# Altium schematic sheet Connector.SchDoc(Connector)
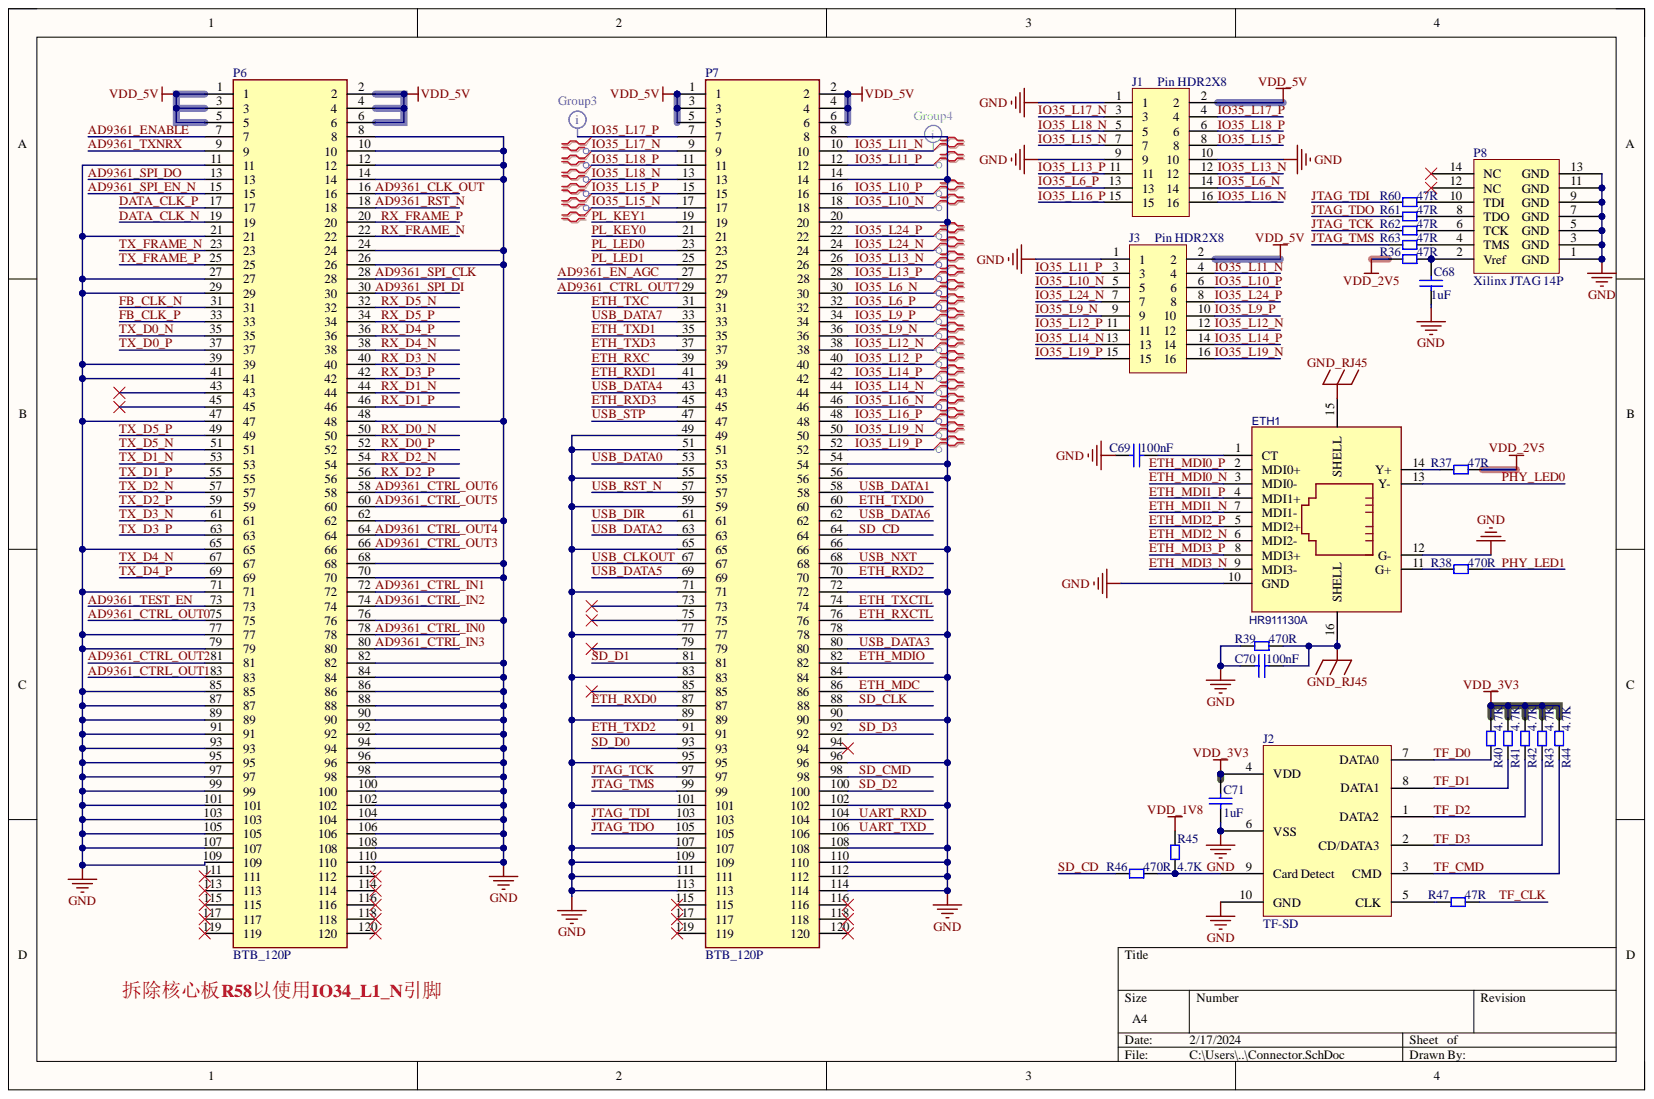
[diagram p0: Connector.SchDoc]

## Parts
C68  1uF  [C0402]
C69  100nF  [C0402]
C70  100nF  [C0402]
C71  1uF  [C0402]
ETH1  HR911130A  [RJ45-TH_HR911130A]
J1  Pin HDR2X8  [Pin HDR2X8/2.54mm-S]
J2  TF-SD  [TF-SD]
J3  Pin HDR2X8  [Pin HDR2X8/2.54mm-S]
P6  BTB_120P  [CONNECT-SMD-120P-0.8mm-Male]
P7  BTB_120P  [CONNECT-SMD-120P-0.8mm-Male]
P8  Xilinx JTAG 14P  [JTAG_XILINX]
R36  47R  [R0402]
R37  47R  [R0402]
R38  470R  [R0402]
R39  470R  [R0402]
R40  4.7K  [R0402]
R41  4.7K  [R0402]
R42  4.7K  [R0402]
R43  4.7K  [R0402]
R44  4.7K  [R0402]
R45  4.7K  [R0402]
R46  470R  [R0402]
R47  47R  [R0402]
R60  47R  [R0402]
R61  47R  [R0402]
R62  47R  [R0402]
R63  47R  [R0402]

## Nets
GND: C68.1 C69.2 C70.2 C71.1 ETH1.10(GND) ETH1.12(G-) J1.1 J1.9 J1.10 J2.6(VSS) J2.10(GND) J3.1 P6.8 P6.10 P6.11 P6.12 P6.14 P6.21 P6.24 P6.26 P6.27 P6.29 P6.39 P6.41 P6.47 P6.48 P6.62 P6.65 P6.68 P6.70 P6.71 P6.76 P6.77 P6.79 P6.82 P6.84 P6.85 P6.86 P6.87 P6.88 P6.89 P6.90 P6.91 P6.92 P6.93 P6.94 P6.95 P6.96 P6.97 P6.98 P6.99 P6.100 P6.101 P6.102 P6.103 P6.104 P6.105 P6.106 P6.107 P6.108 P6.109 P6.110 P7.8 P7.14 P7.20 P7.49 P7.51 P7.54 P7.55 P7.56 P7.59 P7.65 P7.66 P7.71 P7.72 P7.77 P7.78 P7.83 P7.84 P7.89 P7.90 P7.95 P7.96 P7.101 P7.102 P7.107 P7.108 P7.109 P7.110 P7.111 P7.112 P7.113 P7.114 P8.1(GND) P8.3(GND) P8.5(GND) P8.7(GND) P8.9(GND) P8.11(GND) P8.13(GND) R39.1
GND_RJ45: C70.1 ETH1.15(SHELL) ETH1.16(SHELL) R39.2
VDD_1V8: R45.2
VDD_2V5: R36.2 R37.2
VDD_3V3: C71.2 J2.4(VDD) R40.1 R41.1 R42.1 R43.1 R44.1
VDD_5V: J1.2 J3.2 P6.1 P6.2 P6.3 P6.4 P6.5 P6.6 P7.1 P7.2 P7.3 P7.4 P7.5 P7.6
AD9361_CLK_OUT: P6.16
AD9361_CTRL_IN0: P6.78
AD9361_CTRL_IN1: P6.72
AD9361_CTRL_IN2: P6.74
AD9361_CTRL_IN3: P6.80
AD9361_CTRL_OUT0: P6.75
AD9361_CTRL_OUT1: P6.83
AD9361_CTRL_OUT2: P6.81
AD9361_CTRL_OUT3: P6.66
AD9361_CTRL_OUT4: P6.64
AD9361_CTRL_OUT5: P6.60
AD9361_CTRL_OUT6: P6.58
AD9361_CTRL_OUT7: P7.29
AD9361_EN_AGC: P7.27
AD9361_ENABLE: P6.7
AD9361_RST_N: P6.18
AD9361_SPI_CLK: P6.28
AD9361_SPI_DI: P6.30
AD9361_SPI_DO: P6.13
AD9361_SPI_EN_N: P6.15
AD9361_TEST_EN: P6.73
AD9361_TXNRX: P6.9
DATA_CLK_N: P6.19
DATA_CLK_P: P6.17
ETH_MDC: P7.86
ETH_MDI0_N: ETH1.3(MDI0-)
ETH_MDI0_P: ETH1.2(MDI0+)
ETH_MDI1_N: ETH1.7(MDI1-)
ETH_MDI1_P: ETH1.4(MDI1+)
ETH_MDI2_N: ETH1.6(MDI2-)
ETH_MDI2_P: ETH1.5(MDI2+)
ETH_MDI3_N: ETH1.9(MDI3-)
ETH_MDI3_P: ETH1.8(MDI3+)
ETH_MDIO: P7.82
ETH_RXC: P7.39
ETH_RXCTL: P7.76
ETH_RXD0: P7.87
ETH_RXD1: P7.41
ETH_RXD2: P7.70
ETH_RXD3: P7.45
ETH_TXC: P7.31
ETH_TXCTL: P7.74
ETH_TXD0: P7.60
ETH_TXD1: P7.35
ETH_TXD2: P7.91
ETH_TXD3: P7.37
FB_CLK_N: P6.31
FB_CLK_P: P6.33
IO35_L6_N: J1.14 P7.30
IO35_L6_P: J1.13 P7.32
IO35_L9_N: J3.9 P7.36
IO35_L9_P: J3.10 P7.34
IO35_L10_N: J3.5 P7.18
IO35_L10_P: J3.6 P7.16
IO35_L11_N: J3.4 P7.10
IO35_L11_P: J3.3 P7.12
IO35_L12_N: J3.12 P7.38
IO35_L12_P: J3.11 P7.40
IO35_L13_N: J1.12 P7.26
IO35_L13_P: J1.11 P7.28
IO35_L14_N: J3.13 P7.44
IO35_L14_P: J3.14 P7.42
IO35_L15_N: J1.7 P7.17
IO35_L15_P: J1.8 P7.15
IO35_L16_N: J1.16 P7.46
IO35_L16_P: J1.15 P7.48
IO35_L17_N: J1.3 P7.9
IO35_L17_P: J1.4 P7.7
IO35_L18_N: J1.5 P7.13
IO35_L18_P: J1.6 P7.11
IO35_L19_N: J3.16 P7.50
IO35_L19_P: J3.15 P7.52
IO35_L24_N: J3.7 P7.24
IO35_L24_P: J3.8 P7.22
JTAG_TCK: P7.97 R62.2
JTAG_TDI: P7.103 R60.2
JTAG_TDO: P7.105 R61.2
JTAG_TMS: P7.99 R63.2
PHY_LED0: ETH1.13(Y-)
PHY_LED1: R38.2
PL_KEY0: P7.21
PL_KEY1: P7.19
PL_LED0: P7.23
PL_LED1: P7.25
RX_D0_N: P6.50
RX_D0_P: P6.52
RX_D1_N: P6.44
RX_D1_P: P6.46
RX_D2_N: P6.54
RX_D2_P: P6.56
RX_D3_N: P6.40
RX_D3_P: P6.42
RX_D4_N: P6.38
RX_D4_P: P6.36
RX_D5_N: P6.32
RX_D5_P: P6.34
RX_FRAME_N: P6.22
RX_FRAME_P: P6.20
SD_CD: P7.64 R46.2
SD_CLK: P7.88
SD_CMD: P7.98
SD_D0: P7.93
SD_D1: P7.81
SD_D2: P7.100
SD_D3: P7.92
TF_CLK: R47.1
TF_CMD: J2.3(CMD) R44.2
TF_D0: J2.7(DATA0) R40.2
TF_D1: J2.8(DATA1) R41.2
TF_D2: J2.1(DATA2) R42.2
TF_D3: J2.2(CD/DATA3) R43.2
TX_D0_N: P6.35
TX_D0_P: P6.37
TX_D1_N: P6.53
TX_D1_P: P6.55
TX_D2_N: P6.57
TX_D2_P: P6.59
TX_D3_N: P6.61
TX_D3_P: P6.63
TX_D4_N: P6.67
TX_D4_P: P6.69
TX_D5_N: P6.51
TX_D5_P: P6.49
TX_FRAME_N: P6.23
TX_FRAME_P: P6.25
UART_RXD: P7.104
UART_TXD: P7.106
USB_CLKOUT: P7.67
USB_DATA0: P7.53
USB_DATA1: P7.58
USB_DATA2: P7.63
USB_DATA3: P7.80
USB_DATA4: P7.43
USB_DATA5: P7.69
USB_DATA6: P7.62
USB_DATA7: P7.33
USB_DIR: P7.61
USB_NXT: P7.68
USB_RST_N: P7.57
USB_STP: P7.47
NetC68_2: C68.2 P8.2(Vref) R36.1
NetC69_1: C69.1 ETH1.1(CT)
NetETH1_11: ETH1.11(G+) R38.1
NetETH1_14: ETH1.14(Y+) R37.1
NetJ2_5: J2.5(CLK) R47.2
NetJ2_9: J2.9(Card_Detect) R45.1 R46.1
NetP8_4: P8.4(TMS) R63.1
NetP8_6: P8.6(TCK) R62.1
NetP8_8: P8.8(TDO) R61.1
NetP8_10: P8.10(TDI) R60.1

Not connected: P6 43 45 111 112 113 114 115 116 117 118 119 120; P7 73 75 79 85 94 115 116 117 118 119 120; P8 12(NC) 14(NC)

## Library data of the parts
C68-C71 = Cap_NP: CAP no polarity; Component Kind: Standard; Library Name: Common.SchLib
ETH1 = HR911130A: ETH transformer; BOM_Manufacturer: HANRUN(汉仁); BOM_Manufacturer Part: HR915320A; Contributor: LCSC; BOM_JLCPCB Part Class: Extended Part; BOM_Supplier: LCSC; BOM_Supplier Part: C2760327; Component Kind: Standard; Library Name: ZYNQ_SDR.SCHLIB; spicePre: D; spiceSymbolName: HR915320A
J2 = TF-SD: TF-SD Card; Component Kind: Standard; Library Name: Common.SchLib
P6 P7 = BTB_120P: 120Pin 0.8mm BTB Connector; Component Kind: Standard; Library Name: ZYNQ_SDR.SCHLIB
P8 = Xilinx JTAG 14P: Xilinx JTAG 2.0mm*14P; Component Kind: Standard; Library Name: ZYNQ_SDR.SCHLIB
R36-R47 R60-R63 = Res: Component Kind: Standard; Description: Res; Library Name: Common.SchLib
J1 J3 = Pin HDR2X8: Component Kind: Standard; Library Name: 排针.IntLib
